# Altium schematic sheet 103RCT6.SchDoc(103RCT6)
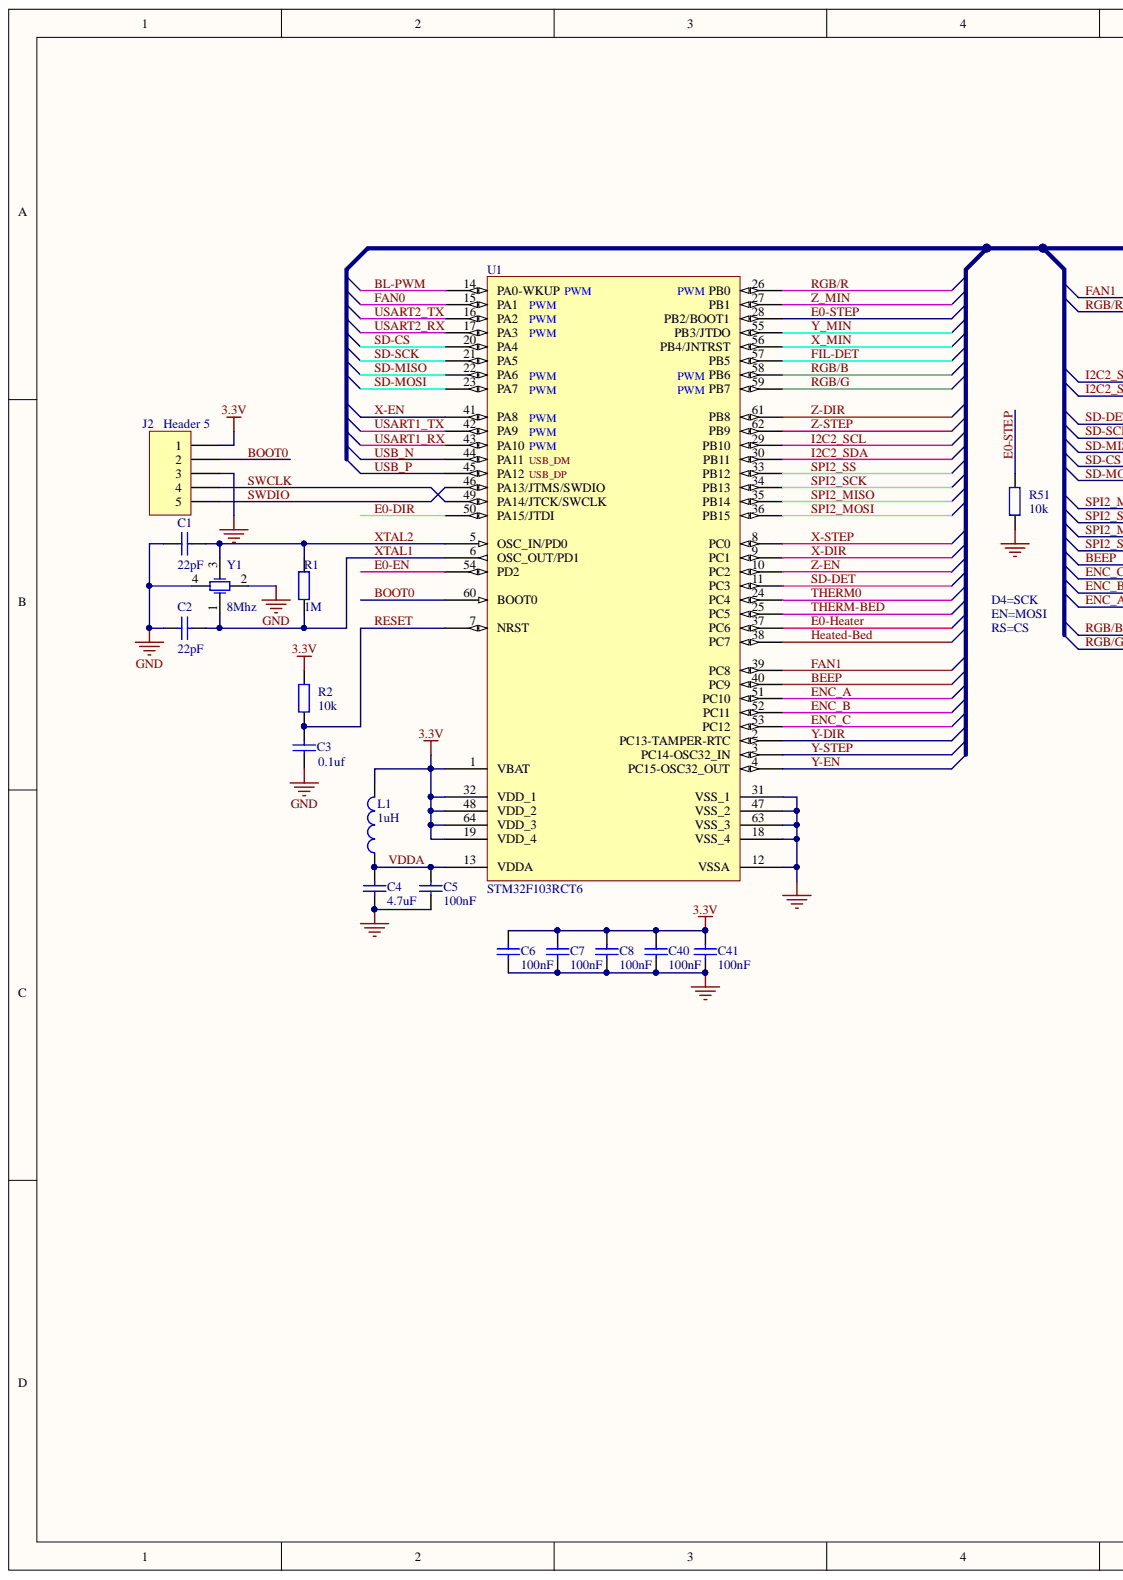
[diagram p0: 103RCT6.SchDoc, left]
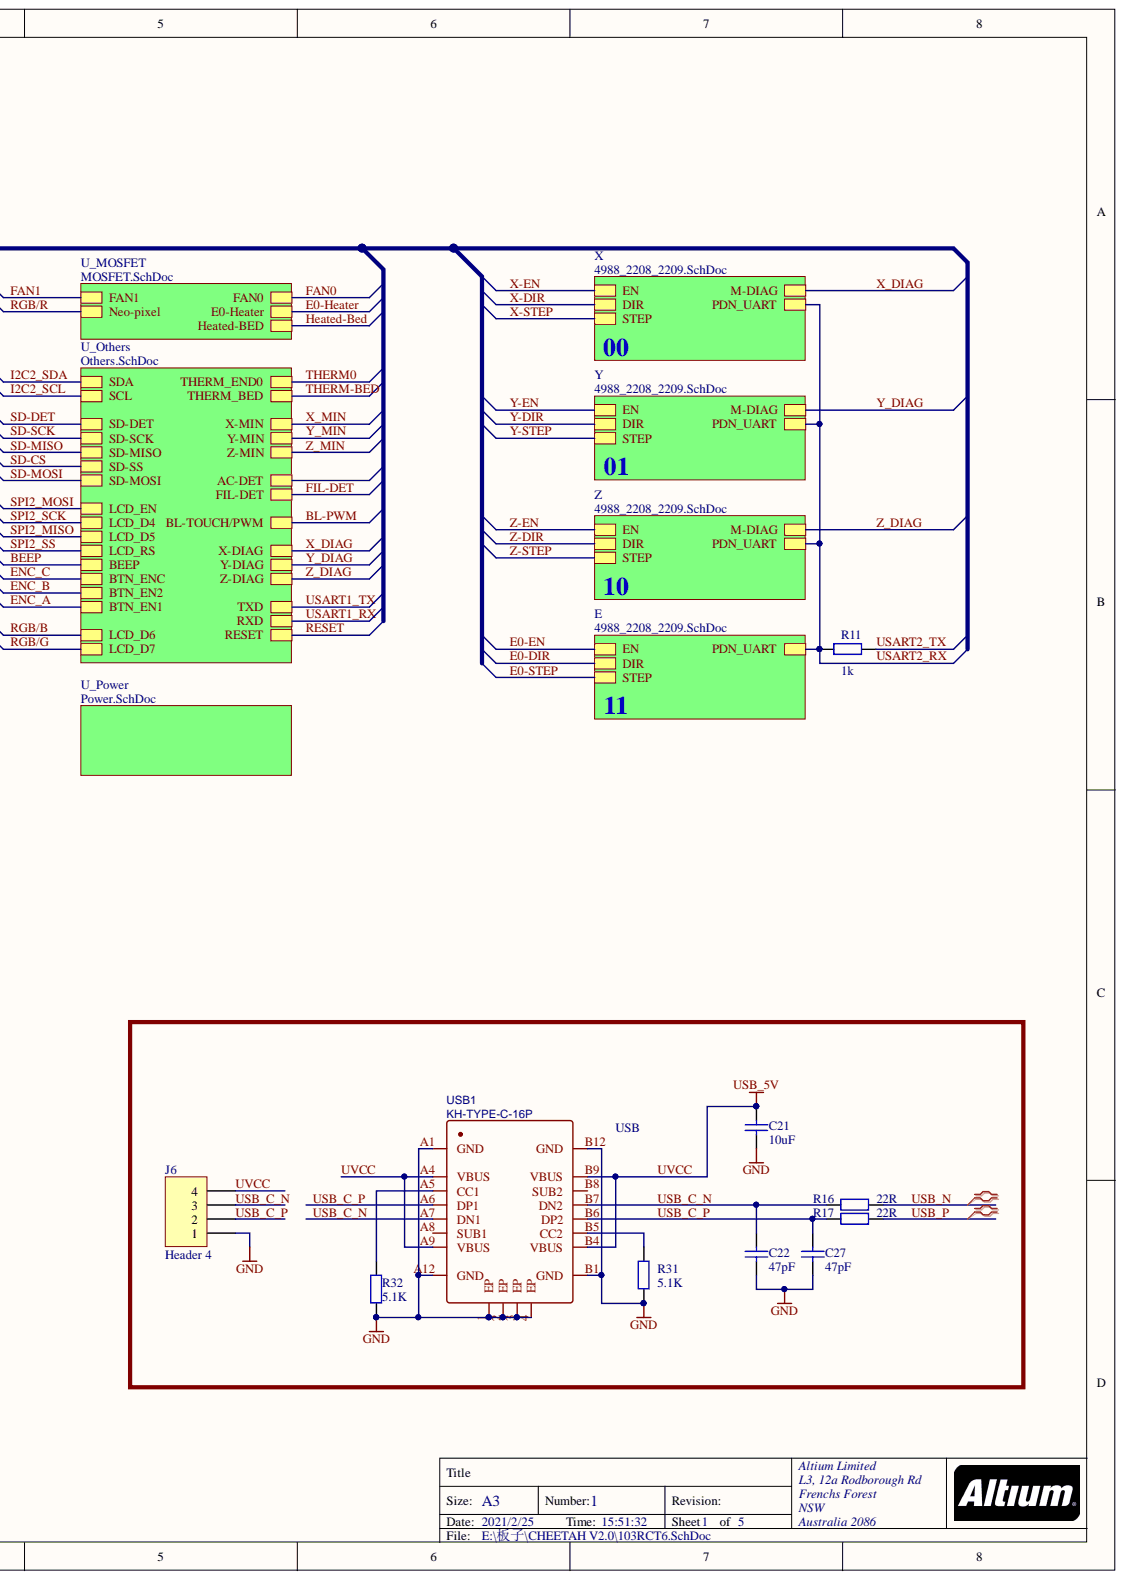
[diagram p1: 103RCT6.SchDoc, right]

## Parts
C1  22pF  [CAP0402]
C2  22pF  [CAP0402]
C3  0.1uF  [CAP0402]
C4  4.7uF  [CAP0402]
C5  100nF  [CAP0402]
C6  100nF  [CAP0402]
C7  100nF  [CAP0402]
C8  100nF  [CAP0402]
C21  10uF  [CAP0805]
C22  47pF  [CAP0402]
C27  47pF  [CAP0402]
C40  100nF  [CAP0402]
C41  100nF  [CAP0402]
J2  Header 5  [HDR1X5]
J6  Header 4  [Header 1X4]
L1  1uH  [RES0603]
R1  1M  [RES0402]
R2  10K  [RES0402]
R11  1K  [RES0402]
R16  22R  [RES0402]
R17  22R  [RES0402]
R31  5.1K  [RES0402]
R32  5.1K  [RES0402]
R51  10K  [RES0402]
U1  STM32F103RCT6  [TQFP64_N]
USB1  KH-TYPE-C-16P  [USB-C-SMD_KH-TYPE-C-16P]
Y1  8Mhz  [ABM8G_3.2x2.5mm]

## Nets
3.3V: C6.1 C7.1 C8.1 C40.1 C41.1 J2.1 L1.2 R2.2 U1.1(VBAT) U1.19(VDD_4) U1.32(VDD_1) U1.48(VDD_2) U1.64(VDD_3)
GND: C1.1 C2.1 C3.1 C4.2 C5.2 C6.2 C7.2 C8.2 C21.1 C22.1 C27.1 C40.2 C41.2 J2.3 J6.1 R31.1 R32.1 R51.1 U1.12(VSSA) U1.18(VSS_4) U1.31(VSS_1) U1.47(VSS_2) U1.63(VSS_3) USB1.1(EP) USB1.2(EP) USB1.3(EP) USB1.4(EP) USB1.A1(GND) USB1.A12(GND) USB1.B1(GND) USB1.B12(GND) Y1.2 Y1.4
BEEP: U1.40(PC9)
BL-PWM: U1.14(PA0-WKUP)
BOOT0: J2.2 U1.60(BOOT0)
E0-DIR: U1.50(PA15/JTDI)
E0-EN: U1.54(PD2)
E0-Heater: U1.37(PC6)
E0-STEP: R51.2 U1.28(PB2/BOOT1)
ENC_A: U1.51(PC10)
ENC_B: U1.52(PC11)
ENC_C: U1.53(PC12)
FAN0: U1.15(PA1)
FAN1: U1.39(PC8)
FIL-DET: U1.57(PB5)
Heated-Bed: U1.38(PC7)
I2C2_SCL: U1.29(PB10)
I2C2_SDA: U1.30(PB11)
RESET: C3.2 R2.1 U1.7(NRST)
RGB/B: U1.58(PWM_PB6)
RGB/G: U1.59(PWM_PB7)
RGB/R: U1.26(PWM_PB0)
SD-CS: U1.20(PA4)
SD-DET: U1.11(PC3)
SD-MISO: U1.22(PA6)
SD-MOSI: U1.23(PA7)
SD-SCK: U1.21(PA5)
SPI2_MISO: U1.35(PB14)
SPI2_MOSI: U1.36(PB15)
SPI2_SCK: U1.34(PB13)
SPI2_SS: U1.33(PB12)
SWCLK: J2.4 U1.49(PA14/JTCK/SWCLK)
SWDIO: J2.5 U1.46(PA13/JTMS/SWDIO)
THERM0: U1.24(PC4)
THERM-BED: U1.25(PC5)
USART1_RX: U1.43(PA10)
USART1_TX: U1.42(PA9)
USART2_RX: R11.2 U1.17(PA3)
USART2_TX: R11.1 U1.16(PA2)
USB_C_N: C22.2 J6.3 R16.1 USB1.A7(DN1) USB1.B7(DN2)
USB_C_P: C27.2 J6.2 R17.1 USB1.A6(DP1) USB1.B6(DP2)
USB_N: R16.2 U1.44(PA11)
USB_P: R17.2 U1.45(PA12)
UVCC: C21.2 J6.4 USB1.A4(VBUS) USB1.A9(VBUS) USB1.B4(VBUS) USB1.B9(VBUS)
VDDA: C4.1 C5.1 L1.1 U1.13(VDDA)
X-DIR: U1.9(PC1)
X-EN: U1.41(PA8)
X-STEP: U1.8(PC0)
X_MIN: U1.56(PB4/JNTRST)
XTAL1: C2.2 R1.1 U1.6(OSC_OUT/PD1) Y1.1
XTAL2: C1.2 R1.2 U1.5(OSC_IN/PD0) Y1.3
Y-DIR: U1.2(PC13-TAMPER-RTC)
Y-EN: U1.4(PC15-OSC32_OUT)
Y-STEP: U1.3(PC14-OSC32_IN)
Y_MIN: U1.55(PB3/JTDO)
Z-DIR: U1.61(PB8)
Z-EN: U1.10(PC2)
Z-STEP: U1.62(PB9)
Z_MIN: U1.27(PB1)
NetR31_2: R31.2 USB1.B5(CC2)
NetR32_2: R32.2 USB1.A5(CC1)
NetUSB1_A8: USB1.A8(SUB1)
NetUSB1_B8: USB1.B8(SUB2)
AC-DET:
N00020:
USB_DM:
USB_DP:
X_DIAG:
Y_DIAG:
Z_DIAG:

## Library data of the parts
C1-C3 = Cap: Capacitor; Published: 8-Jun-2000; PackageReference: RAD-0.3; Library Name: Miscellaneous Devices.IntLib; LatestRevisionNote: Re-released for DXP Platform.; LatestRevisionDate: 17-Jul-2002; Component Kind: Standard; Publisher: Altium Limited
  C1 C2: Value: 22pF; Simulation: CAP; Signal Integrity: Cap; PackageDescription: Radial Cap, Thru-Hole; 2 Leads; 0.3 in Pin Spacing
  C3: Value: 0.1uf
C4 = Capacitor_1: RO X5R 10UF 10% 35V 1206; ID: Library_CAP-0005; Faber ItemCode: 35436800; Value: 4.7uF; Component Kind: Standard; Library Name: Ultimaker.IntLib
C5-C8 C40 C41 = Capacitor: RO X7R 100nF 10% 50V 0603; ID: Library_CAP-0002; Faber ItemCode: 45068500; Value: 100nF; Component Kind: Standard; Library Name: Ultimaker.SCHLIB
C21 C22 C27 = Library_CAP-0002: RO X7R 100nF 10% 50V 0603; Faber ItemCode: 45068500; ID: Library_CAP-0002; Component Kind: Standard; Library Name: Ultimaker.IntLib
  C21: Value: 10uF
  C22 C27: Value: 47pF
J2 = Header 5: Header, 5-Pin; Signal Integrity: Connector; Library Name: Miscellaneous Connectors.IntLib; LatestRevisionNote: Re-released for DXP Platform.; LatestRevisionDate: 17-Jul-2002; Component Kind: Standard; Publisher: Altium Limited
J6 = Header 4: Header, 4-Pin; Signal Integrity: Connector; Library Name: Miscellaneous Connectors.IntLib; LatestRevisionNote: Re-released for DXP Platform.; LatestRevisionDate: 17-Jul-2002; Component Kind: Standard; Publisher: Altium Limited
L1 = Inductor: Value: 100uH; Revision: July-2002: Re-released for DXP Platform.; Published: 8-Jun-2000; Library Name: Miscellaneous Devices.IntLib; Description: Inductor; Component Kind: Standard; Publisher: Altium Limited
R1 R2 R11 R31 R32 R51 = Res2: Resistor; Published: 8-Jun-2000; PackageReference: AXIAL-0.4; Library Name: Miscellaneous Devices.IntLib; LatestRevisionNote: Re-released for DXP Platform.; LatestRevisionDate: 17-Jul-2002; Component Kind: Standard; Publisher: Altium Limited
  R1: Value: 1M; Simulation: RESISTOR; Signal Integrity: Res; PackageDescription: Axial Device, Thru-Hole; 2 Leads; 0.4 in Pin Spacing
  R2 R51: Value: 10k
  R11: Value: 1k
  R31 R32: Value: 5.1K
R16 R17 = Library_RES-0007: RO RES 22E0 1% 0,063W 0603; Info: Resistor; Faber ItemCode: 36108300; Value: 22R; ID: Library_RES-0007; Component Kind: Standard; Library Name: Ultimaker.IntLib
U1 = STM32F103RBT6: STM32 ARM-based 32-bit MCU with 128 Kbytes Flash, 64-pin LQFP, Industrial Temperature; Published: 30-May-2008; PackageVersion: Mar-2008; PackageReference: LQFP64; PackageDescription: 64-Pin Low-Profile Quad Flat Pack, 10 x 10 mm Body, 0.5 mm Pitch (LQFP64); Library Name: ST Microcontroller 32-Bit STM32.IntLib; DatasheetVersion: rev6, Mar-2008; ComponentLink2URL: http://www.st.com/stonline/products/literature/ds/13587.pdf; ComponentLink2Description: Datasheet; ComponentLink1URL: www.st.com/stm32; ComponentLink1Description: Manufacturer Link; Component Kind: Standard; Publisher: Altium Limited
USB1 = KH-TYPE-C-16P: BOM_Manufacturer: Shenzhen Kinghelm Elec; Contributor: LCSC; spiceSymbolName: KH-TYPE-C-16P; spicePre: U; Component Kind: Standard; BOM_Supplier Part: C709357; BOM_Supplier: LCSC; BOM_Manufacturer Part: KH-TYPE-C-16P
Y1 = Crystal_4: Component Kind: Standard; Library Name: 接插件库.SCHLIB
